# Altium schematic sheet eBox_Peripherals.SchDoc
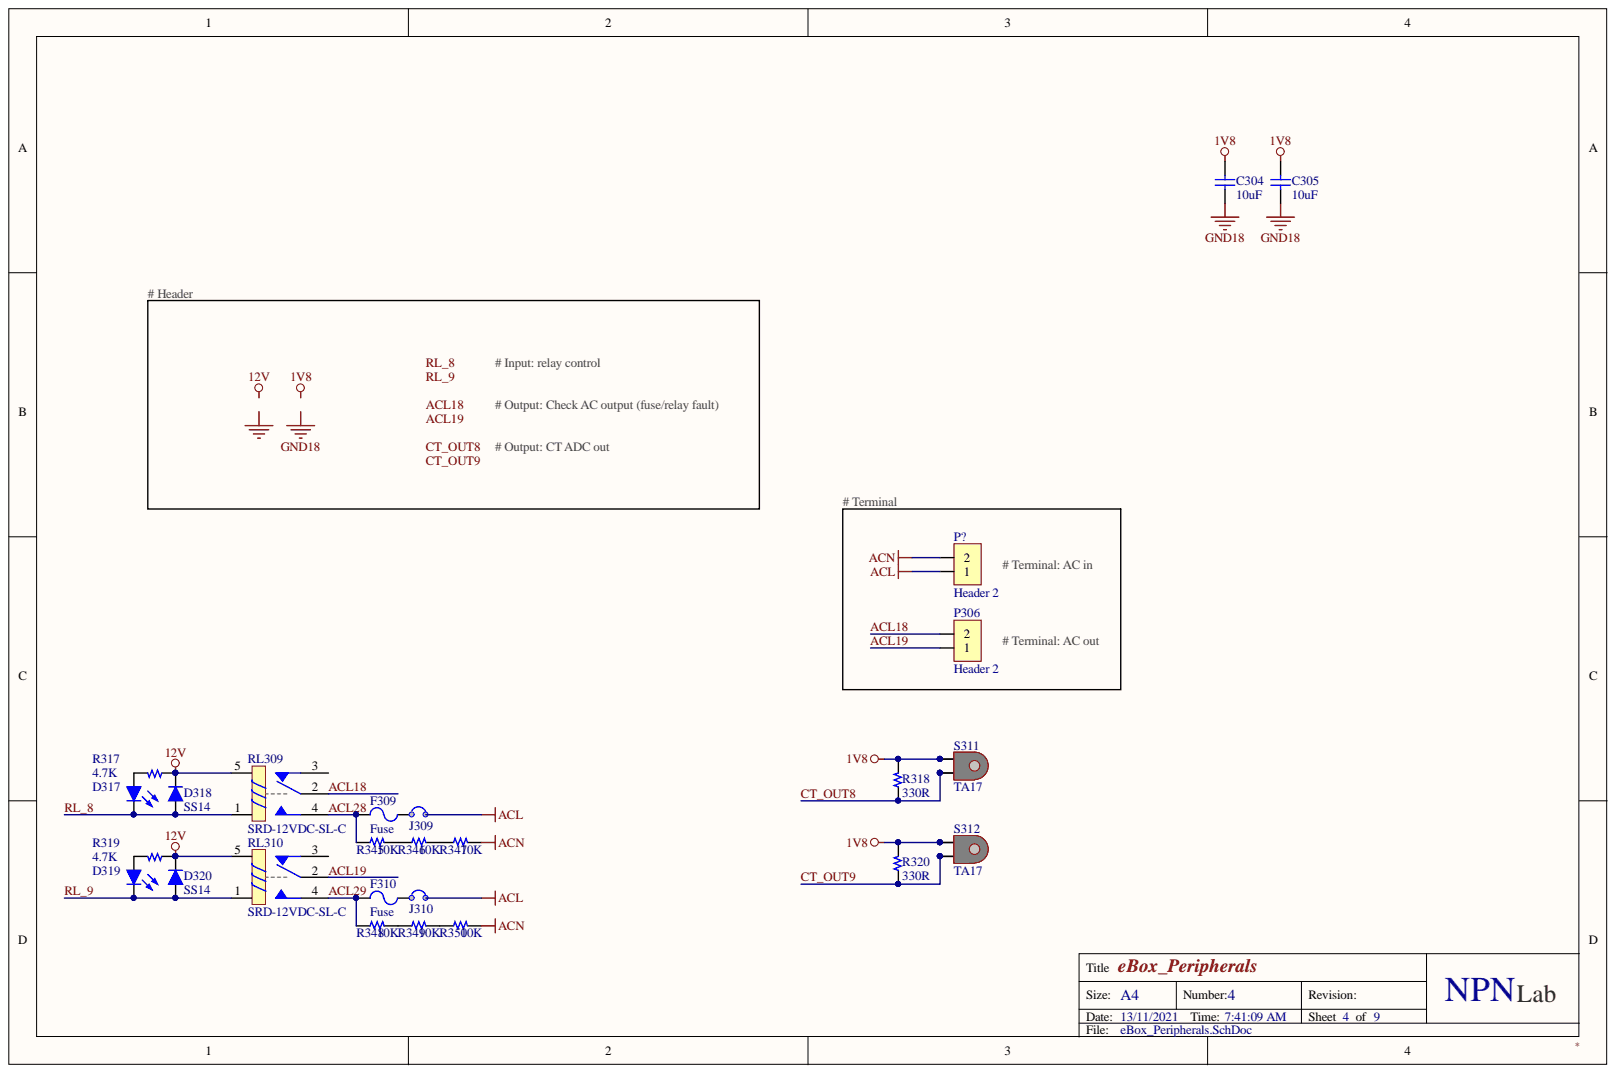
[diagram p0: eBox_Peripherals.SchDoc]

## Parts
C304  10uF  [C 0805]
C305  10uF  [C 0805]
D317  Led  [LED 3MM - green]  (value not drawn)
D318  SS14  [DO-214AC (SMA) L]
D319  Led  [LED 3MM - green]  (value not drawn)
D320  SS14  [DO-214AC (SMA) L]
F309  Fuse  [FUSE 2 DIP 0520 BOX]
F310  Fuse  [FUSE 2 DIP 0520 BOX]
J309  JUMP 2 CUSTOM  [JUMP/2/Custom]  (value not drawn)  (no BOM)
J310  JUMP 2 CUSTOM  [JUMP/2/Custom]  (value not drawn)  (no BOM)
P306  Header 2  [HDR/Terminal/2/10mm]
P?  Header 2  [HDR/Terminal/2/10mm]
R317  4.7K  [R 0805]
R318  330R  [R 0805]
R319  4.7K  [R 0805]
R320  330R  [R 0805]
R345  10K  [R 1206]
R346  10K  [R 1206]
R347  10K  [R 1206]
R348  10K  [R 1206]
R349  10K  [R 1206]
R350  10K  [R 1206]
RL309  SRD-12VDC-SL-C  [RL_SPDT_10A]
RL310  SRD-12VDC-SL-C  [RL_SPDT_10A]
S309  TA12-200  [TA12]  (value not drawn)
S310  NA  [TA12]  (value not drawn)
S311  TA17  [TA17]
S312  TA17  [TA17]

## Nets
1V8: C304.1 C305.1 R318.2 R320.2 S309.1 S310.1 S311.1 S312.1
12V: D318.2 D320.2 R317.1 R319.1 RL309.5 RL310.5
ACL: J309.2 J310.2 P?.1
ACN: P?.2 R347.2 R350.2
GND18: C304.2 C305.2
ACL18: P306.2 RL309.2
ACL19: P306.1 RL310.2
ACL28: F309.1 R345.1 RL309.4
ACL29: F310.1 R348.1 RL310.4
CT_OUT8: R318.1 S309.2 S311.2
CT_OUT9: R320.1 S310.2 S312.2
RL_8: D317.2 D318.1 RL309.1
RL_9: D319.2 D320.1 RL310.1
NetD317_1: D317.1 R317.2
NetD319_1: D319.1 R319.2
NetF309_2: F309.2 J309.1
NetF310_2: F310.2 J310.1
NetR345_2: R345.2 R346.1
NetR346_2: R346.2 R347.1
NetR348_2: R348.2 R349.1
NetR349_2: R349.2 R350.1
GND:

Not connected: RL309 3; RL310 3

## Library data of the parts
C304 C305 = Capacitor NP: CAP CER 0.1UF 50V X7R 0805; TYPE: SMD; Component Kind: Standard; Library Name: chipfc_altium_libs.IntLib; PACKAGE: SMD0805; STOCK CODE: CL21B104KBNC; STOCK DESC: 100nF/50V ±10% X7R SMD-0805 Capacitor; SUPPLIER: tme.vn; UNIT PRICE: 600
D317 D319 = Led: LED RED CLEAR 0805 SMD; Component Kind: Standard; Library Name: chipfc_altium_libs.IntLib; PACKAGE: SMD0805; STOCK CODE: HT17-2102SURC; STOCK DESC: SMD-0805 High Bright Red Chip LED; SUPPLIER: tme.vn; TYPE: SMD; UNIT PRICE: 800
D318 D320 = Diode: DIODE SCHOTTKY 40V 1A SMA; BRAND: Toshiba; TYPE: SMD; Component Kind: Standard; Library Name: chipfc_altium_libs.IntLib; PACKAGE: DO-214AC; PART NO: SS14; STOCK CODE: SS14; STOCK DESC: 1A 40V Schottky Barrier Diode; SUPPLIER: tme.vn; UNIT PRICE: 1000
P306 P? = Header 2: Header, 2-Pin; Component Kind: Standard; Library Name: chipfc_altium_libs.IntLib; UNIT PRICE: 0
R317 R319 = Resistor: RES SMD 4.7K OHM 1% 1/10W 0805; Component Kind: Standard; Library Name: chipfc_altium_libs.IntLib; PACKAGE: SMD0805; STOCK CODE: RM10JTN472; STOCK DESC: 4.7K 5% SMD-0805 Resistor; SUPPLIER: tme.vn; TYPE: SMD; UNIT PRICE: 60
R318 R320 = Resistor: RES SMD 680 OHM 1% 1/10W 0805; Component Kind: Standard; Library Name: chipfc_altium_libs.IntLib; PACKAGE: SMD0805; STOCK CODE: RM10JTN681; STOCK DESC: 680R 5% SMD-0805 Resistor; SUPPLIER: tme.vn; TYPE: SMD; UNIT PRICE: 60
R345-R350 = Resistor: RES SMD 10K OHM 5% 1/4W 1206; Component Kind: Standard; Library Name: chipfc_altium_libs.IntLib; PACKAGE: SMD1206; STOCK DESC: RES SMD 10K OHM 5% 1/4W 1206; SUPPLIER: banlinhkien.com; TYPE: SMD; UNIT PRICE: 240
RL309 RL310 = Relay SPDT: RELAY GEN PURPOSE SPDT 10A 12V; BRAND: SONGLE; Component Kind: Standard; Library Name: chipfc_altium_libs.IntLib; PART NO: SRD-12VDC-SL-C; STOCK CODE: SRD-12VDC-SL-C; STOCK DESC: Relay SRD-12VDC-SL-C; SUPPLIER: tme.vn; TYPE: TH; UNIT PRICE: 10000
S309 = TA12: CURR SENSE 1:2000 5A T/H; BRAND: YHDC; Component Kind: Standard; Library Name: chipfc_altium_libs.IntLib; PART NO: TA12-200; SUPPLIER: CHINA; TYPE: TH; UNIT PRICE: 22328
S310 = TA12: CURR SENSE 1:2000 5A T/H; PART NO: TA12-200; BRAND: YHDC; Component Kind: Standard; Library Name: chipfc_altium_libs.IntLib; SUPPLIER: CHINA; TYPE: TH; UNIT PRICE: 22328
F309 F310 = Fuse: Component Kind: Standard; Description: Fuse; Library Name: chipfc_altium_libs.IntLib; UNIT PRICE: 0
J309 J310 = JUMP 2 CUSTOM: Library Name: chipfc_altium_libs.IntLib; TYPE: NO-BOM; UNIT PRICE: 0
S311 S312 = TA17: Component Kind: Standard; Library Name: common_altium_libs.IntLib
